# Altium schematic sheet Power_Supply.SchDoc
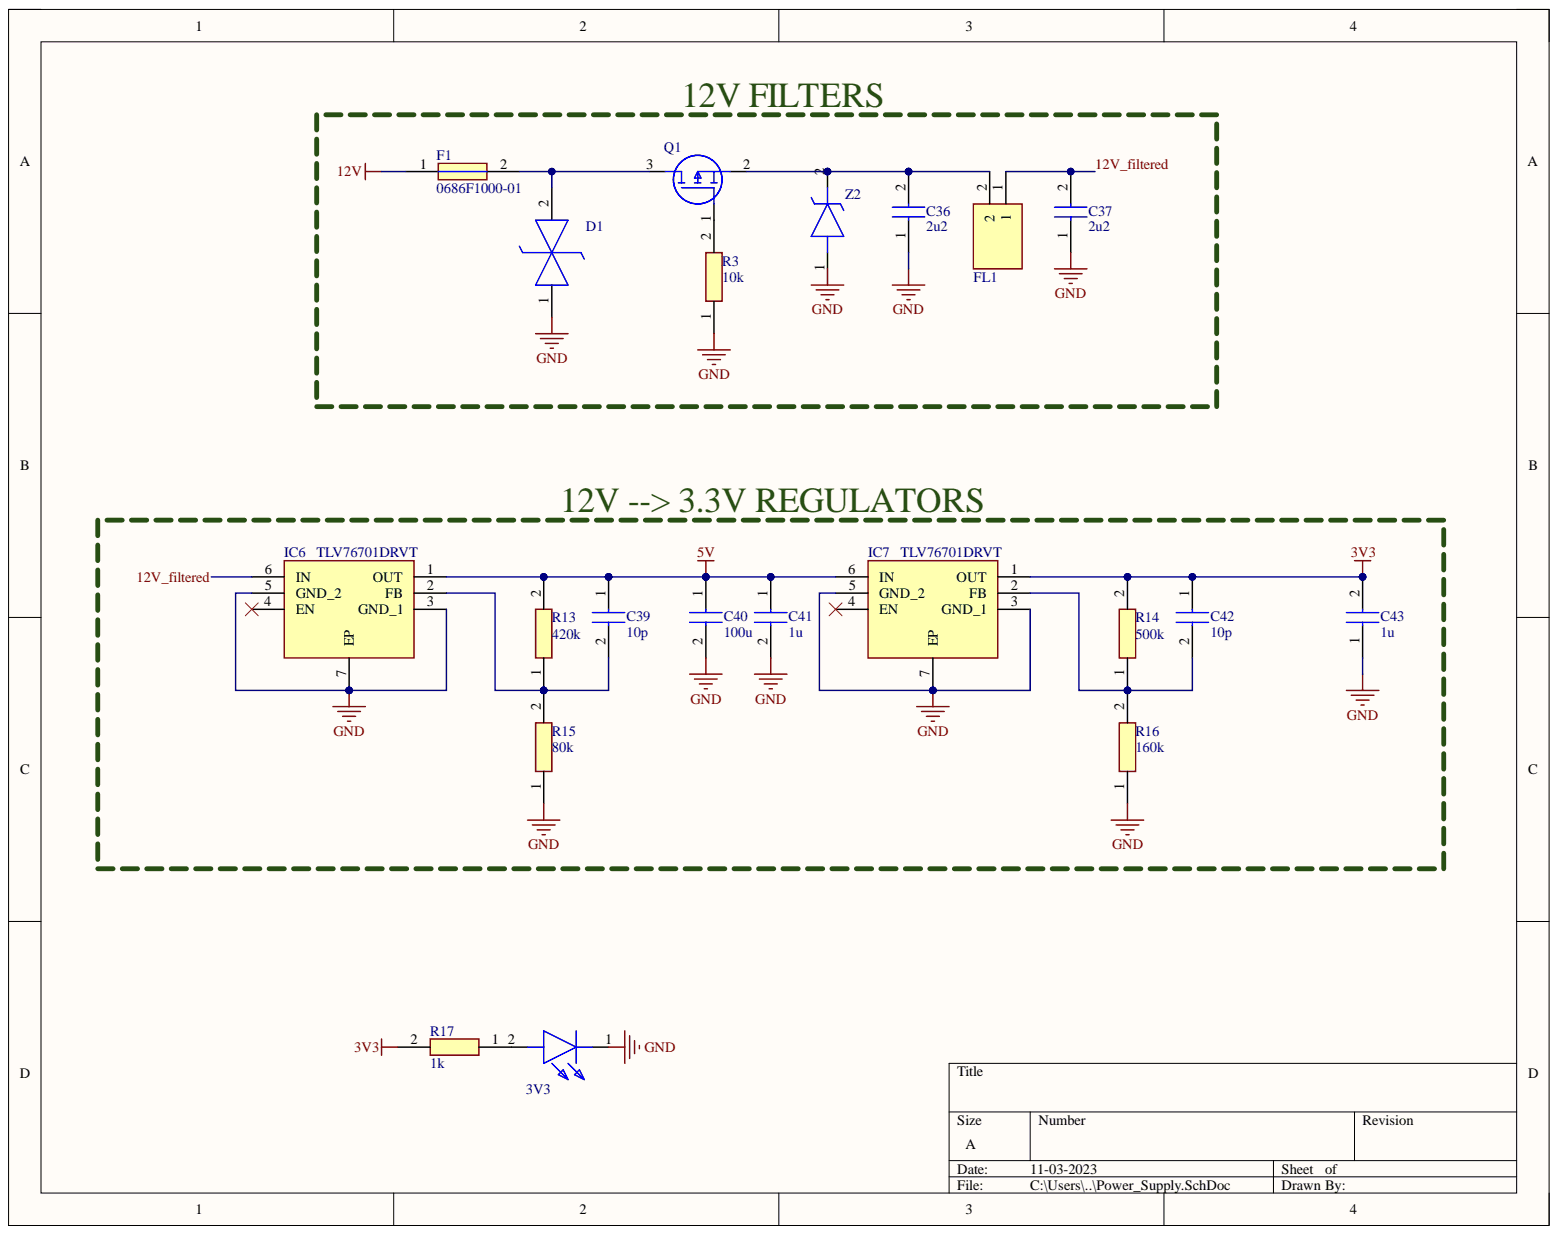
[diagram p0: Power_Supply.SchDoc]

## Parts
3V3  LTST-C170KRKT  [LEDC2012X120N]  (value not drawn)
C36  2u2  [CAPC1608X90N]
C37  2u2  [CAPC1608X90N]
C39  10p  [CAPC1005X55N]
C40  100u  [CAPC1608X90N]
C41  1u  [CAPC1608X90N]
C42  10p  [CAPC1005X55N]
C43  1u  [CAPC1608X90N]
D1  PESD15VL1BA,115  [SOD2512X110N]  (value not drawn)
F1  0686F1000-01  [FUSC1608X60N]
FL1  BLM18PG181SN1D  [BLM18AG102BH1D]  (value not drawn)
IC6  TLV76701DRVT  [SON65P200X200X80-7N]
IC7  TLV76701DRVT  [SON65P200X200X80-7N]
Q1  RRR030P03HZG  [SOT95P280X90-3N]  (value not drawn)
R3  10k  [RESC1005X35N]
R13  420k  [RESC1005X35N]
R14  500k  [RESC1005X35N]
R15  80k  [RESC1005X35N]
R16  160k  [RESC1005X35N]
R17  1k  [RESC1005X35N]
Z2  PLZ13B-G3_H  [PLZ13BG3H]  (value not drawn)

## Nets
3V3: C42.1 C43.2 IC7.1(OUT) R14.2 R17.2
5V: C39.1 C40.1 C41.1 IC6.1(OUT) IC7.6(IN) R13.2
12V: F1.1
GND: 3V3.1 C36.1 C37.1 C40.2 C41.2 C43.1 D1.1 IC6.3(GND_1) IC6.5(GND_2) IC6.7(EP) IC7.3(GND_1) IC7.5(GND_2) IC7.7(EP) R3.1 R15.1 R16.1 Z2.1
12V_filtered: C37.2 FL1.1 IC6.6(IN)
Net3V3_2: 3V3.2 R17.1
NetC36_2: C36.2 FL1.2 Q1.2 Z2.2
NetC39_2: C39.2 IC6.2(FB) R13.1 R15.2
NetC42_2: C42.2 IC7.2(FB) R14.1 R16.2
NetD1_2: D1.2 F1.2 Q1.3
NetQ1_1: Q1.1 R3.2

Not connected: IC6 4(EN); IC7 4(EN)

## Library data of the parts
3V3 = LTST-C170KRKT: LED; Manufacturer_Name: Lite-On; Arrow Part Number: LTST-C170KRKT; Arrow Price/Stock: https://www.arrow.com/en/products/ltst-c170krkt/lite-on-technology?region=nac; Component Kind: Standard; Datasheet Link: https://componentsearchengine.com/Datasheets/1/LTST-C170KRKT.pdf; Height: 1.2mm; Manufacturer_Part_Number: LTST-C170KRKT; Mouser Part Number: 859-LTST-C170KRKT; Mouser Price/Stock: https://www.mouser.co.uk/ProductDetail/Lite-On/LTST-C170KRKT?qs=NUb82WqeCyrVOID%2Fxt4rgA%3D%3D
C36 C37 C40 C41 C43 = 06035C101K4T2A: 0603 Capacitor; Manufacturer_Name: AVX; Component Kind: Standard; Datasheet Link: https://componentsearchengine.com/Datasheets/1/06031A100FAT2A.pdf; Height: 0.9mm; Library Name: Schlib1.SchLib; Manufacturer_Part_Number: 06035C101K4T2A; Mouser Part Number: 581-06035C101K4T2A; Mouser Price/Stock: https://www.mouser.co.uk/ProductDetail/AVX/06035C101K4T2A/?qs=%252Bwax1qqoKK9kpvNfpp2NFA%3D%3D
C39 C42 = 06035C101K4T2A: 0402 Capacitor; Manufacturer_Name: AVX; Component Kind: Standard; Datasheet Link: https://componentsearchengine.com/Datasheets/1/06031A100FAT2A.pdf; Height: 0.9mm; Library Name: Schlib1.SchLib; Manufacturer_Part_Number: 06035C101K4T2A; Mouser Part Number: 581-06035C101K4T2A; Mouser Price/Stock: https://www.mouser.co.uk/ProductDetail/AVX/06035C101K4T2A/?qs=%252Bwax1qqoKK9kpvNfpp2NFA%3D%3D
D1 = PESD15VL1BA,115: Diode ESD (Bi-directional); Manufacturer_Name: Nexperia; Arrow Part Number: PESD15VL1BA,115; Arrow Price/Stock: https://www.arrow.com/en/products/pesd15vl1ba115/nexperia?region=nac; Component Kind: Standard; Datasheet Link: https://assets.nexperia.com/documents/data-sheet/PESDXL1BA_SER.pdf; Height: 1.1mm; Manufacturer_Part_Number: PESD15VL1BA,115; Mouser Part Number: 771-PESD15VL1BA-T/R; Mouser Price/Stock: https://www.mouser.co.uk/ProductDetail/Nexperia/PESD15VL1BA115?qs=LOCUfHb8d9vibm295AC%252Bdg%3D%3D
F1 = 0686F1000-01: 1A Fuse; Manufacturer_Name: Bel Circuit Protection; Arrow Part Number: 0686F1000-01; Arrow Price/Stock: https://www.arrow.com/en/products/0686f1000-01/bel-group?region=nac; Component Kind: Standard; Datasheet Link: https://componentsearchengine.com/Datasheets/2/0686F1000-01.pdf; Height: 0.6mm; Manufacturer_Part_Number: 0686F1000-01
FL1 = BLM18PG181SN1D: Filter; Manufacturer_Name: Murata Electronics; Arrow Part Number: BLM18PG181SN1D; Arrow Price/Stock: https://www.arrow.com/en/products/blm18pg181sn1d/murata-manufacturing?region=nac; Component Kind: Standard; Datasheet Link: https://www.murata.com/en-us/products/productdetail?partno=BLM18PG181SN1%23; Height: 0.95mm; Manufacturer_Part_Number: BLM18PG181SN1D; Mouser Part Number: 81-BLM18PG181SN1D; Mouser Price/Stock: https://www.mouser.co.uk/ProductDetail/Murata-Electronics/BLM18PG181SN1D?qs=sTaz8HHMol3W3rq0NJIneg%3D%3D
IC6 IC7 = TLV76701DRVT: Integrated Circuit; Manufacturer_Name: Texas Instruments; Arrow Part Number: TLV76701DRVT; Arrow Price/Stock: https://www.arrow.com/en/products/tlv76701drvt/texas-instruments; Component Kind: Standard; Datasheet Link: http://www.ti.com/lit/gpn/TLV767; Height: 0.8mm; Manufacturer_Part_Number: TLV76701DRVT; Mouser Part Number: 595-TLV76701DRVT; Mouser Price/Stock: https://www.mouser.co.uk/ProductDetail/Texas-Instruments/TLV76701DRVT?qs=l7cgNqFNU1h6riEEGEkQYw%3D%3D
Q1 = RRR030P03HZG: MOSFET (P-Channel); Manufacturer_Name: ROHM Semiconductor; Component Kind: Standard; Datasheet Link: https://fscdn.rohm.com/en/products/databook/datasheet/discrete/transistor/mosfet/rrr030p03hzgtl-e.pdf; Height: 0.9mm; Manufacturer_Part_Number: RRR030P03HZG
R3 R13-R17 = CR0603-J_-1R0ELF: 0402 Resistor; Manufacturer_Name: Bourns; Manufacturer_Part_Number: CR0603-J/-1R0ELF; Component Kind: Standard; Datasheet Link: https://www.bourns.com/pdfs/chpreztr.pdf; Height: 0.55mm; Mouser Part Number: 652-CR0603-J/-1R0ELF; Mouser Price/Stock: https://www.mouser.com/Search/Refine.aspx?Keyword=652-CR0603-J%2F-1R0ELF
Z2 = PLZ13B-G3_H: Zener Diode; Manufacturer_Name: Vishay; Manufacturer_Part_Number: PLZ13B-G3/H; Arrow Part Number: PLZ13B-G3/H; Arrow Price/Stock: https://www.arrow.com/en/products/plz13b-g3h/vishay; Component Kind: Standard; Datasheet Link: https://www.vishay.com/docs/84830/plzseries.pdf; Height: 0.7mm; Mouser Part Number: 78-PLZ13B-G3/H; Mouser Price/Stock: https://www.mouser.co.uk/ProductDetail/Vishay-Semiconductors/PLZ13B-G3-H?qs=ZTNTdOMgtc2okmH7KVbgIQ%3D%3D
